# Altium schematic sheet Page G_ZLG_3G.SchDoc
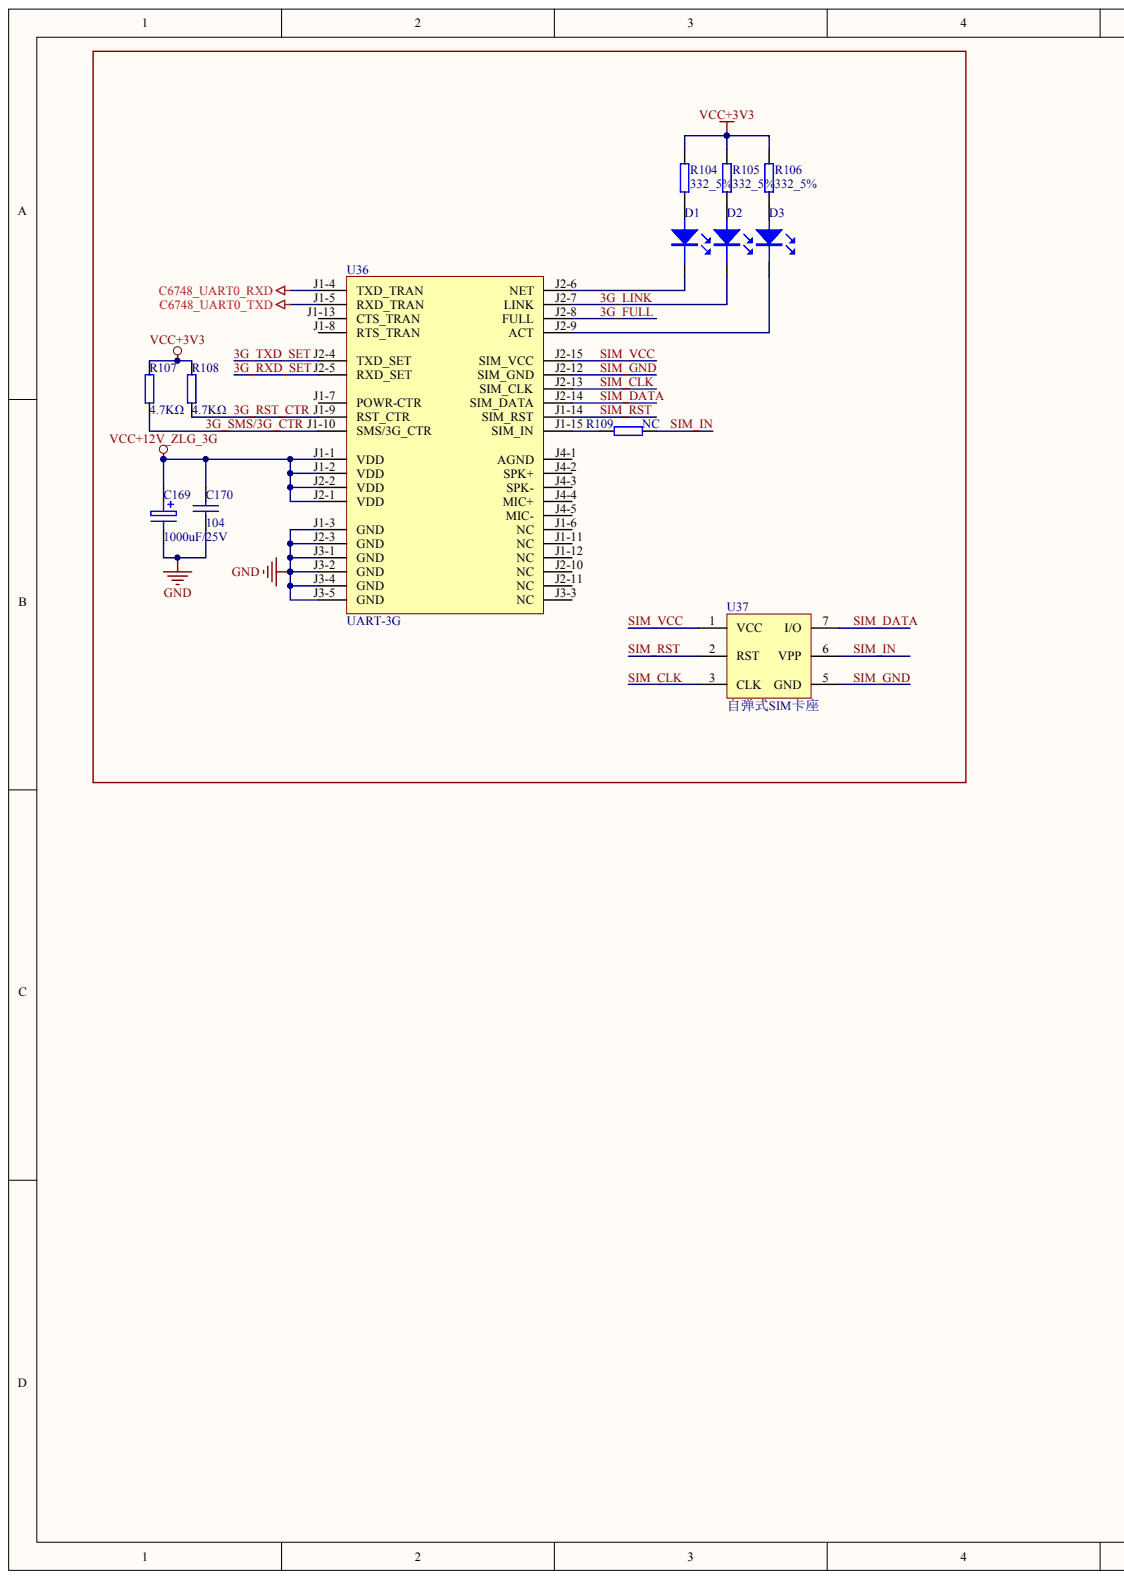
[diagram p0: Page G_ZLG_3G.SchDoc, left]
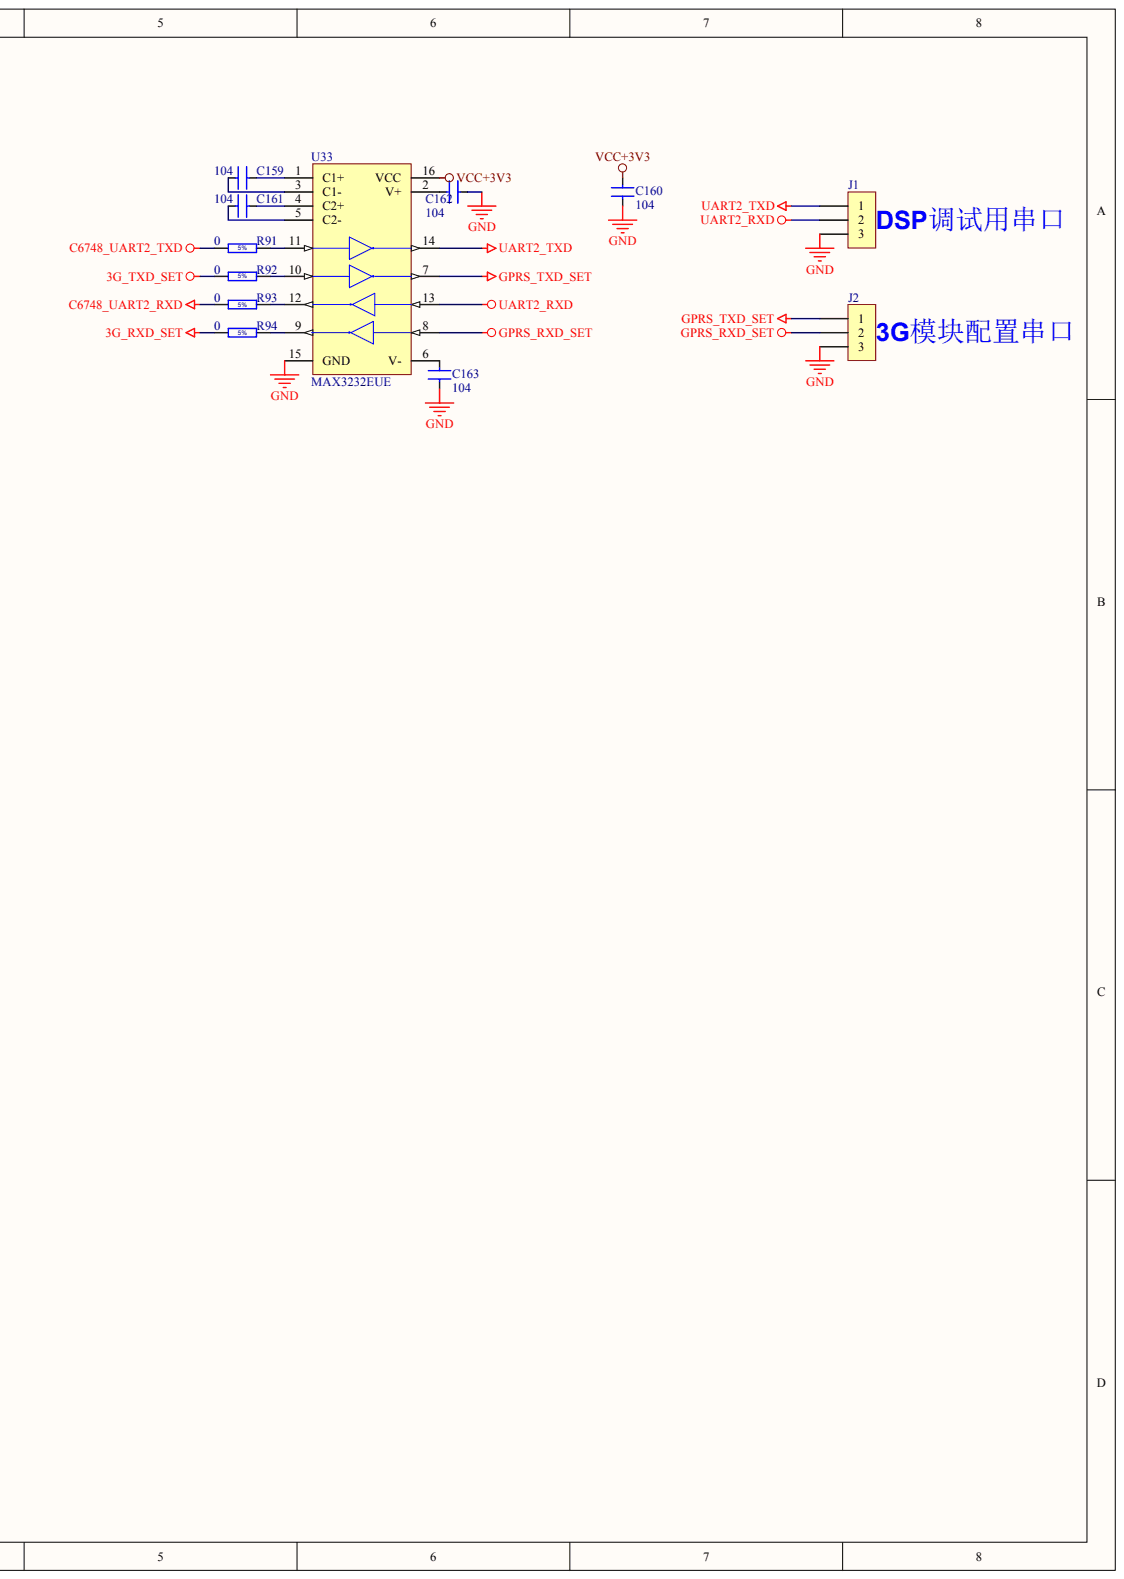
[diagram p1: Page G_ZLG_3G.SchDoc, right]

## Parts
C159  104
C160  104
C161  104
C162  104
C163  104
C169  1000uF/25V
C170  104
D1  LED  (value not drawn)
D2  LED  (value not drawn)
D3  LED  (value not drawn)
J1  (no value)
J2  (no value)
R91  0
R92  0
R93  0
R94  0
R104  332_5%
R105  332_5%
R106  332_5%
R107  4.7KΩ
R108  4.7KΩ
R109  NC
U33  MAX3232EUE
U36  UART-3G
U37  自弹式SIM卡座

## Nets
C6748_UART0_RXD: U36.J1-4(TXD_TRAN)
C6748_UART0_TXD: U36.J1-5(RXD_TRAN)
C6748_UART2_RXD: R93.1
C6748_UART2_TXD: R91.1
GND: C160.1 C162.2 C163.2 C169.2 C170.2 J1.3 J2.3 U33.15(GND) U36.J1-3(GND) U36.J2-3(GND) U36.J3-1(GND) U36.J3-2(GND) U36.J3-4(GND) U36.J3-5(GND)
GPRS_RXD_SET: J2.2 U33.8
GPRS_TXD_SET: J2.1 U33.7
UART2_RXD: J1.2 U33.13
UART2_TXD: J1.1 U33.14
VCC+3V3: C160.2 R104.1 R105.1 R106.1 R107.1 R108.1 U33.16(VCC)
VCC+12V_ZLG_3G: C169.1 C170.1 U36.J1-1(VDD) U36.J1-2(VDD) U36.J2-1(VDD) U36.J2-2(VDD)
3G_FULL: U36.J2-8(FULL)
3G_LINK: D2.K U36.J2-7(LINK)
3G_RST_CTR: R108.2 U36.J1-9(RST_CTR)
3G_RXD_SET: R94.1 U36.J2-5(RXD_SET)
3G_SMS/3G_CTR: R107.2 U36.J1-10(SMS/3G_CTR)
3G_TXD_SET: R92.1 U36.J2-4(TXD_SET)
SIM_CLK: U36.J2-13(SIM_CLK) U37.3(CLK)
SIM_DATA: U36.J2-14(SIM_DATA) U37.7(I/O)
SIM_GND: U36.J2-12(SIM_GND) U37.5(GND)
SIM_IN: R109.2 U37.6(VPP)
SIM_RST: U36.J1-14(SIM_RST) U37.2(RST)
SIM_VCC: U36.J2-15(SIM_VCC) U37.1(VCC)
NetC159_1: C159.1 U33.1(C1+)
NetC159_2: C159.2 U33.3(C1-)
NetC161_1: C161.1 U33.4(C2+)
NetC161_2: C161.2 U33.5(C2-)
NetC162_1: C162.1 U33.2(V+)
NetC163_1: C163.1 U33.6(V-)
NetD1_A: D1.A R104.2
NetD1_K: D1.K U36.J2-6(NET)
NetD2_A: D2.A R105.2
NetD3_A: D3.A R106.2
NetD3_K: D3.K U36.J2-9(ACT)
NetR91_2: R91.2 U33.11
NetR92_2: R92.2 U33.10
NetR93_2: R93.2 U33.12
NetR94_2: R94.2 U33.9
NetR109_1: R109.1 U36.J1-15(SIM_IN)

Not connected: U36 J1-6(NC) J1-7(POWR-CTR) J1-8(RTS_TRAN) J1-11(NC) J1-12(NC) J1-13(CTS_TRAN) J2-10(NC) J2-11(NC) J3-3(NC) J4-1(AGND) J4-2(SPK+) J4-3(SPK-) J4-4(MIC+) J4-5(MIC-)

## Library data of the parts
C170 = (no symbol name): Value: 10uf
R107-R109 = (no symbol name): Value: 1K
C159-C163 C169 D1-D3 J1 J2 R91-R94 R104-R106 U33 U36 U37 = (no symbol name)
  U33: Published: 16-Jan-2001; LatestRevisionDate: 06-Jun-2005; LatestRevisionNote: Stylized 3D Model Added.; DatasheetVersion: Mar-1999; PackageVersion: 21-0066 C; PackageReference: TSSOP16; PackageDescription: Shrink Small Outline; 16 Leads; Body Width 4.4 mm; Pitch 0.65 mm; ComponentLink1Description: Manufacturer Link; ComponentLink1URL: http://www.maxim-ic.com/; ComponentLink2Description: Datasheet; ComponentLink2URL: http://pdfserv.maxim-ic.com/en/ds/MAX3222-MAX3241.pdf; Publisher: Altium Limited
